# Altium schematic sheet PWR_M_V1.SchDoc
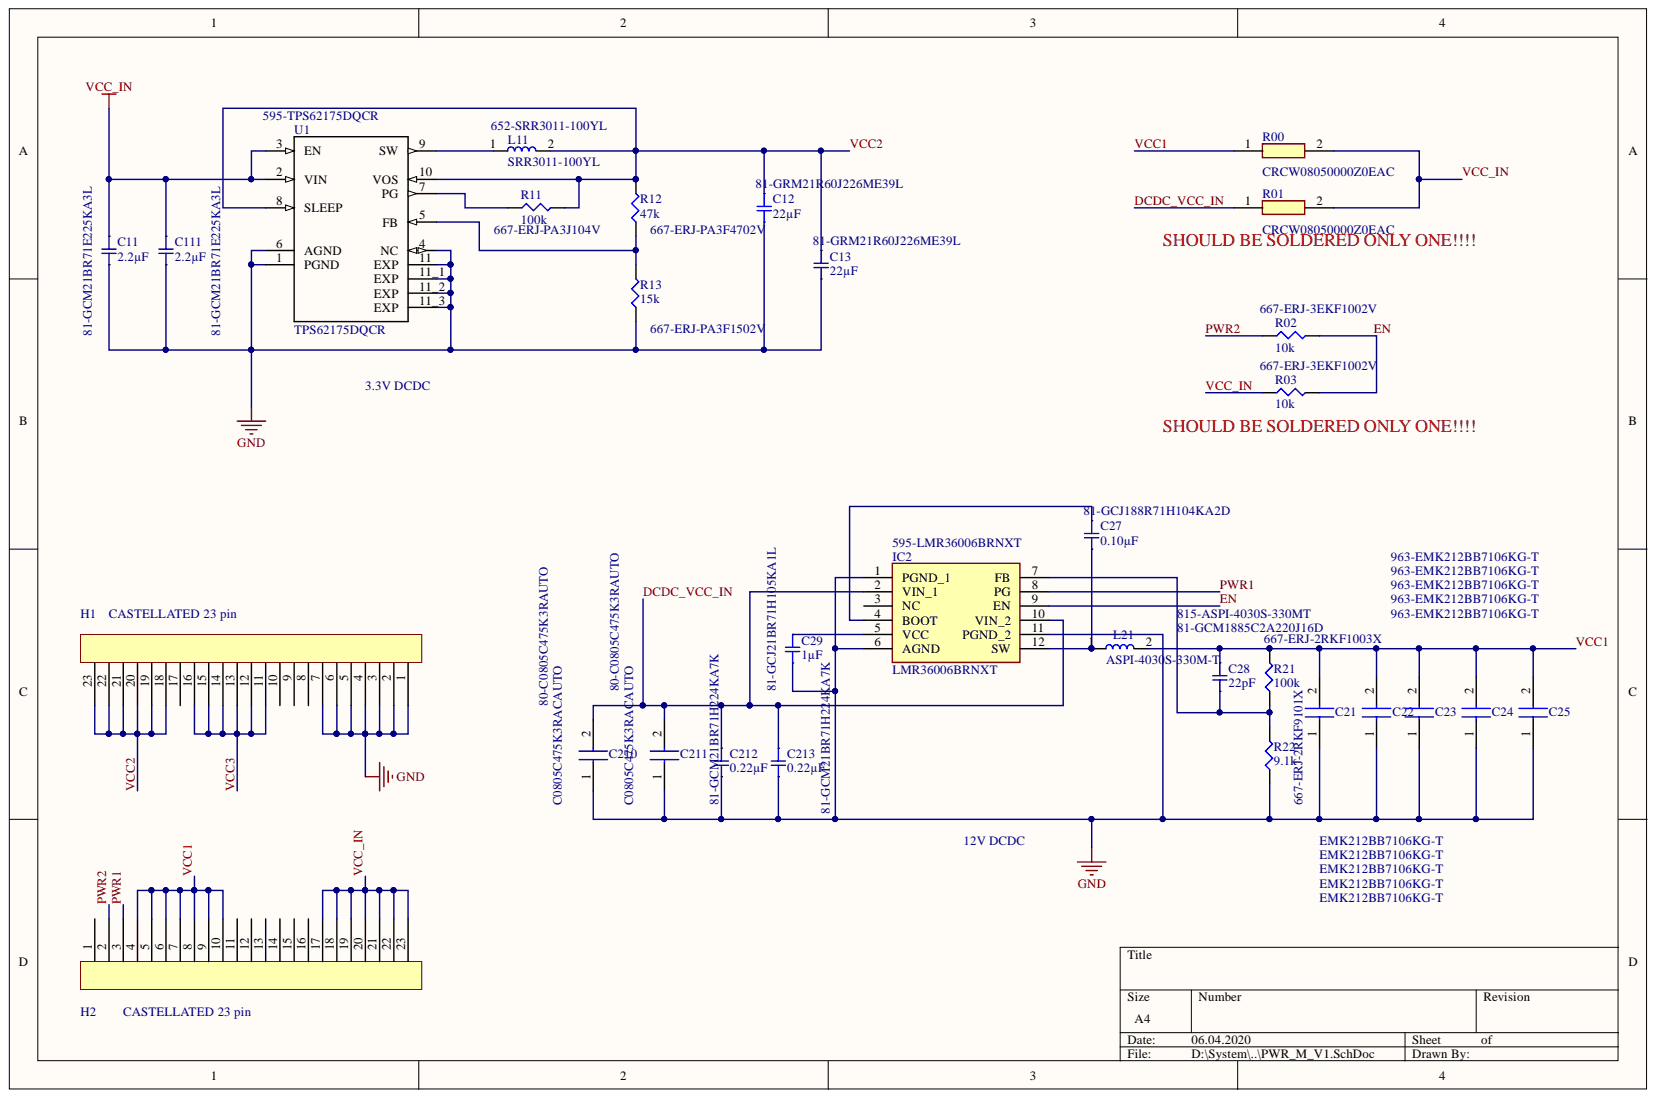
[diagram p0: PWR_M_V1.SchDoc]

## Parts
C11  2.2µF  Supplier Part Number 1: 81-GCM21BR71E225KA3L  [SMD-0805C]
C12  22µF  Supplier Part Number 1: 81-GRM21R60J226ME39L  [SMD-0805C]
C13  22µF  Supplier Part Number 1: 81-GRM21R60J226ME39L  [SMD-0805C]
C21  EMK212BB7106KG-T  [CAPC2012X135N]
C22  EMK212BB7106KG-T  [CAPC2012X135N]
C23  EMK212BB7106KG-T  [CAPC2012X135N]
C24  EMK212BB7106KG-T  [CAPC2012X135N]
C25  EMK212BB7106KG-T  [CAPC2012X135N]
C27  0.10µF  Supplier Part Number 1: 81-GCJ188R71H104KA2D  [SMD-0603C]
C28  22pF  [SMD-0603C]
C29  1µF  Supplier Part Number 1: 81-GCJ21BR71H105KA1L  [SMD-0805C]
C111  2.2µF  [SMD-0805C]
C210  C0805C475K3RACAUTO  [CAPC2012X125N]
C211  C0805C475K3RACAUTO  [CAPC2012X125N]
C212  0.22µF  Supplier Part Number 1: 81-GCM21BR71H224KA7K  [SMD-0805C]
C213  0.22µF  [SMD-0805C]
H1  CASTELLATED 23 pin  [CASTELLATED 23 pin]
H2  CASTELLATED 23 pin  [CASTELLATED 23 pin]
IC2  LMR36006BRNXT  Mouser2 Part Number: 595-LMR36006BRNXT  [LMR36015FSCQRNXTQ1]
L11  SRR3011-100YL  Supplier Part Number 1: 652-SRR3011-100YL  [SRR3011-100YL]
L21  ASPI-4030S-330M-T  [INDPM4040X300N]
R00  CRCW08050000Z0EAC  [RESC2012X60N]
R01  CRCW08050000Z0EAC  [RESC2012X60N]
R02  10k  Supplier Part Number 1: 667-ERJ-3EKF1002V  [SMD-0603-RES]
R03  10k  Supplier Part Number 1: 667-ERJ-3EKF1002V  [SMD-0603-RES]
R11  100k  Supplier Part Number 1: 667-ERJ-PA3J104V  [SMD-0603-RES]
R12  47k  [SMD-0603-RES]
R13  15k  Supplier Part Number 1: 667-ERJ-PA3F1502V  [SMD-0603-RES]
R21  100k  Supplier Part Number 1: 667-ERJ-2RKF1003X  [SMD-0402-RES]
R22  9.1k  Supplier Part Number 1: 667-ERJ-2RKF9101X  [SMD-0402-RES]
U1  TPS62175DQCR  Supplier Part Number 1: 595-TPS62175DQCR  [*SON50P300X200X80-11N240X84_TPS62175DQCR(Primary)]

## Nets
GND: C11.2 C12.2 C13.2 C21.1 C22.1 C23.1 C24.1 C25.1 C29.2 C111.2 C210.1 C211.1 C212.2 C213.2 H1.1 H1.2 H1.3 H1.4 H1.5 H1.6 H1.7 IC2.1(PGND_1) IC2.6(AGND) IC2.11(PGND_2) R13.1 R22.1 U1.1(PGND) U1.4(NC) U1.6(AGND) U1.11(EXP) U1.11_1(EXP) U1.11_2(EXP) U1.11_3(EXP)
DCDC_VCC_IN: C210.2 C211.2 C212.1 C213.1 IC2.2(VIN_1) IC2.10(VIN_2) R01.1
EN: IC2.9(EN) R02.2 R03.2
PWR1: H2.3 IC2.8(PG)
PWR2: H2.2 R02.1
VCC1: C21.2 C22.2 C23.2 C24.2 C25.2 C28.1 H2.4 H2.5 H2.6 H2.7 H2.8 H2.9 H2.10 L21.2 R00.1 R21.2
VCC2: C12.1 C13.1 H1.18 H1.19 H1.20 H1.21 H1.22 H1.23 L11.2 R11.2 R12.2 U1.8(SLEEP) U1.10(VOS)
VCC3: H1.11 H1.12 H1.13 H1.14 H1.15 H1.16
VCC_IN: C11.1 C111.1 H2.17 H2.18 H2.19 H2.20 H2.21 H2.22 H2.23 R00.2 R01.2 R03.1 U1.2(VIN) U1.3(EN)
NetC27_1: C27.1 IC2.4(BOOT)
NetC27_2: C27.2 IC2.12(SW) L21.1
NetC28_2: C28.2 IC2.7(FB) R21.1 R22.2
NetC29_1: C29.1 IC2.5(VCC)
NetL11_1: L11.1 U1.9(SW)
NetR11_1: R11.1 U1.7(PG)
NetR12_1: R12.1 R13.2 U1.5(FB)

Not connected: H1 8 9 10 17; H2 1 11 12 13 14 15 16; IC2 3(NC)

## Library data of the parts
C11 C111 = GCM21BR71E225KA73L: CAP CER 2.2UF 25V X7R 0805; Manufacturer: Murata Electronics North America; @ qty: 0; Minimum Quantity: 1; Tolerance: ±10%; Unit Price (USD): 0.35; Applications: Automotive; Component Kind: Standard; Datasheets: http://www.murata.com/~/media/webrenewal/support/library/catalog/products/capacitor/mlcc/c03e.ashx; Digi-Key Part Number: 490-4787-1-ND; Factory Stock: 0; Features: -; Height - Seated (Max): -; Image: //media.digikey.com/photos/Murata%20Photos/NCP,GCM%20SERIES%200805.jpg; Lead Spacing: -; Lead Style: -; Library Name: capacitor_murata_0805.IntLib; Mounting Type: Surface Mount, MLCC; Operating Temperature: -55°C ~ 125°C; Package / Case: 0805 (2012 Metric); Packaging: Cut Tape (CT); Part Number: GCM21BR71E225KA73L; Part Status: Active; Quantity Available: 18461; Ratings: AEC-Q200; Series: GCM; Size / Dimension: 0.079" L x 0.049" W (2.00mm x 1.25mm); Supplier 1: Mouser; Temperature Coefficient: X7R; Thickness (Max): 0.055" (1.40mm); Value: 2.2µF; Voltage: 25V
  C111: Supplier Part Number 1: 81-GCM21BR71E225KA3L
C12 C13 = GRM21BR60J226ME39L: CAP CER 22UF 6.3V X5R 0805; Manufacturer: Murata Electronics North America; @ qty: 0; Database Table Name: capacitor_murata_0805$; Minimum Quantity: 1; Tolerance: ±20%; Unit Price (USD): 0,24; Applications: General Purpose; Component Kind: Standard; Datasheets: http://www.murata.com/~/media/webrenewal/support/library/catalog/products/capacitor/mlcc/c02e.pdf; Digi-Key Part Number: 490-1719-1-ND; Factory Stock: 0; Features: -; Height - Seated (Max): -; Image: //media.digikey.com/Renders/Murata%20Renders/0805%20(2012%20Metric)%20.85mm.jpg; Lead Spacing: -; Lead Style: -; Library Name: cap_murata.DbLib; Mounting Type: Surface Mount, MLCC; Operating Temperature: -55°C ~ 85°C; Package / Case: 0805 (2012 Metric); Packaging: Cut Tape (CT); Part Number: GRM21BR60J226ME39L; Part Status: Active; Quantity Available: 983766; Ratings: -; Series: GRM; Size / Dimension: 0.079" L x 0.049" W (2.00mm x 1.25mm); Supplier 1: Mouser; Temperature Coefficient: X5R; Thickness (Max): 0.053" (1.35mm); Value: 22µF; Voltage: 6.3V
C21-C25 = EMK212BB7106KG-T: Capacitor; Manufacturer_Name: TAIYO YUDEN; Component Kind: Standard; Height: 1.35mm; Manufacturer_Part_Number: EMK212BB7106KG-T; Supplier 1: Mouser; Supplier Part Number 1: 963-EMK212BB7106KG-T
C27 = GCJ188R71H104KA12D: CAP CER 0.1UF 50V X7R 0603; Manufacturer: Murata Electronics North America; @ qty: 0; Minimum Quantity: 1; Tolerance: ±10%; Unit Price (USD): 0.12; Applications: Automotive, Boardflex Sensitive; Component Kind: Standard; Datasheets: http://www.murata.com/~/media/webrenewal/support/library/catalog/products/capacitor/mlcc/c03e.ashx; Digi-Key Part Number: 490-5798-1-ND; Factory Stock: 0; Features: Soft Termination; Height - Seated (Max): -; Image: //media.digikey.com/Renders/Murata%20Renders/0603%28LQG18%29.jpg; Lead Spacing: -; Lead Style: -; Library Name: capacitor_murata_0603.IntLib; Mounting Type: Surface Mount, MLCC; Operating Temperature: -55°C ~ 125°C; Package / Case: 0603 (1608 Metric); Packaging: Cut Tape (CT); Part Number: GCJ188R71H104KA12D; Part Status: Active; Quantity Available: 163695; Ratings: AEC-Q200; Series: GCJ; Size / Dimension: 0.063" L x 0.031" W (1.60mm x 0.80mm); Supplier 1: Mouser; Temperature Coefficient: X7R; Thickness (Max): 0.035" (0.90mm); Value: 0.10µF; Voltage: 50V
C28 = GCM1885C2A220JA16D: CAP CER 22PF 100V NP0 0603; Manufacturer: Murata Electronics North America; @ qty: 0; Minimum Quantity: 1; Temperature Coefficient: C0G, NP0; Tolerance: ±5%; Unit Price (USD): 0.11; Applications: Automotive; Component Kind: Standard; Datasheets: http://www.murata.com/~/media/webrenewal/support/library/catalog/products/capacitor/mlcc/c03e.ashx; Digi-Key Part Number: 490-4942-1-ND; Factory Stock: 0; Features: -; Height - Seated (Max): -; Image: //media.digikey.com/Renders/Murata%20Renders/0603%28GCM188%29.jpg; Lead Spacing: -; Lead Style: -; Library Name: capacitor_murata_0603.IntLib; Mounting Type: Surface Mount, MLCC; Operating Temperature: -55°C ~ 125°C; Package / Case: 0603 (1608 Metric); Packaging: Cut Tape (CT); Part Number: GCM1885C2A220JA16D; Part Status: Active; Quantity Available: 39916; Ratings: AEC-Q200; Series: GCM; Size / Dimension: 0.063" L x 0.031" W (1.60mm x 0.80mm); Supplier 1: Mouser; Supplier Part Number 1: 81-GCM1885C2A220J16D; Thickness (Max): 0.035" (0.90mm); Value: 22pF; Voltage: 100V
C29 = GCJ21BR71H105KA01L: CAP CER 1UF 50V X7R 0805; Manufacturer: Murata Electronics North America; @ qty: 0; Minimum Quantity: 1; Tolerance: ±10%; Unit Price (USD): 0.33; Applications: Automotive, Boardflex Sensitive; Component Kind: Standard; Datasheets: http://www.murata.com/~/media/webrenewal/support/library/catalog/products/capacitor/mlcc/c03e.ashx; Digi-Key Part Number: 490-10667-1-ND; Factory Stock: 0; Features: Soft Termination; Height - Seated (Max): -; Image: //media.digikey.com/Renders/Murata%20Renders/0805%20(2012%20Metric)%20.85mm.jpg; Lead Spacing: -; Lead Style: -; Library Name: capacitor_murata_0805.IntLib; Mounting Type: Surface Mount, MLCC; Operating Temperature: -55°C ~ 125°C; Package / Case: 0805 (2012 Metric); Packaging: Cut Tape (CT); Part Number: GCJ21BR71H105KA01L; Part Status: Active; Quantity Available: 55137; Ratings: AEC-Q200; Series: GCJ; Size / Dimension: 0.079" L x 0.049" W (2.00mm x 1.25mm); Supplier 1: Mouser; Temperature Coefficient: X7R; Thickness (Max): 0.057" (1.45mm); Value: 1µF; Voltage: 50V
C210 C211 = C0805C475K3RACAUTO: Capacitor; Manufacturer_Name: Kemet; Component Kind: Standard; Datasheet Link: https://componentsearchengine.com/Datasheets/1/C0805C475K3RACAUTO.pdf; Height: 1.25mm; Manufacturer_Part_Number: C0805C475K3RACAUTO; Mouser2 Part Number: 80-C0805C475K3RAUTO; Mouser2 Price/Stock: https://www.mouser.com/Search/Refine.aspx?Keyword=80-C0805C475K3RAUTO; Supplier 1: Mouser; Supplier Part Number 1: 80-C0805C475K3RAUTO
C212 C213 = GCM21BR71H224KA37K: CAP CER 0.22UF 50V X7R 0805; Manufacturer: Murata Electronics North America; @ qty: 0; Minimum Quantity: 1; Tolerance: ±10%; Unit Price (USD): 0.24; Applications: Automotive; Component Kind: Standard; Datasheets: http://www.murata.com/~/media/webrenewal/support/library/catalog/products/capacitor/mlcc/c03e.ashx; Digi-Key Part Number: 490-13311-1-ND; Factory Stock: 0; Features: -; Height - Seated (Max): -; Image: //media.digikey.com/photos/Murata%20Photos/NCP,GCM%20SERIES%200805.jpg; Lead Spacing: -; Lead Style: -; Library Name: capacitor_murata_0805.IntLib; Mounting Type: Surface Mount, MLCC; Operating Temperature: -55°C ~ 125°C; Package / Case: 0805 (2012 Metric); Packaging: Cut Tape (CT); Part Number: GCM21BR71H224KA37K; Part Status: Active; Quantity Available: 9007; Ratings: AEC-Q200; Series: GCM; Size / Dimension: 0.079" L x 0.049" W (2.00mm x 1.25mm); Supplier 1: Mouser; Temperature Coefficient: X7R; Thickness (Max): 0.055" (1.40mm); Value: 0.22µF; Voltage: 50V
  C213: Supplier Part Number 1: 81-GCM21BR71H224KA7K
IC2 = LMR36006BRNXT: Integrated Circuit; Manufacturer_Name: Texas Instruments; Component Kind: Standard; Datasheet Link: http://www.ti.com/lit/gpn/LMR36006; Height: 0.9mm; Manufacturer_Part_Number: LMR36006BRNXT; Mouser2 Price/Stock: https://www.mouser.com/Search/Refine.aspx?Keyword=595-LMR36006BRNXT; Supplier 1: Mouser; Supplier Part Number 1: 595-LMR36006BRNXT
R00 R01 = CRCW08050000Z0EAC: Resistor; Manufacturer_Name: Vishay; Component Kind: Standard; Datasheet Link: https://componentsearchengine.com/Datasheets/1/CRCW08050000Z0EAC.pdf; Height: 0.6mm; Manufacturer_Part_Number: CRCW08050000Z0EAC; Mouser2 Part Number: 71-CRCW08050000Z0EAC; Mouser2 Price/Stock: https://www.mouser.com/Search/Refine.aspx?Keyword=71-CRCW08050000Z0EAC
R02 R03 = ERJ-3EKF1002V: RES SMD 10K OHM 1% 1/10W 0603; Manufacturer: Panasonic Electronic Components; @ qty: 1500; Factory Stock: 0; Minimum Quantity: 1; Power (Watts): 0.1W, 1/10W; Temperature Coefficient: ±100ppm/°C; Unit Price (USD): 0.00379; Component Kind: Standard; Composition: Thick Film; Datasheets: http://industrial.panasonic.com/www-cgi/jvcr13pz.cgi?E+PZ+3+AOA0002+ERJ3EKF1002V+7+WW; Digi-Key Part Number: P10.0KHCT-ND; Failure Rate: -; Features: Automotive AEC-Q200; Height - Seated (Max): 0.022" (0.55mm); Image: //media.digikey.com/Photos/Panasonic%20Photos/MFG_ERJ-P6W%20Series.jpg; Library Name: Panasonic_ERJ_0603.IntLib; Number of Terminations: 2; Operating Temperature: -55°C ~ 155°C; Package / Case: 0603 (1608 Metric); Packaging: Cut Tape (CT); Part Number: ERJ-3EKF1002V; Part Status: Active; Quantity Available: 6703141; Series: ERJ; Size / Dimension: 0.063" L x 0.031" W (1.60mm x 0.80mm); Supplier 1: Mouser; Supplier Device Package: 0603; Tolerance: ±1%; Value: 10k
R11 = ERJ-PA3J104V: RES SMD 100K OHM 5% 1/4W 0603; Manufacturer: Panasonic Electronic Components; @ qty: 1500; Factory Stock: 0; Minimum Quantity: 1; Power (Watts): 0.25W, 1/4W; Temperature Coefficient: ±200ppm/°C; Unit Price (USD): 0.01646; Component Kind: Standard; Composition: Thick Film; Datasheets: https://industrial.panasonic.com/cdbs/www-data/pdf/RDA0000/AOA0000C243.pdf; Digi-Key Part Number: P100KBZCT-ND; Failure Rate: -; Features: Automotive AEC-Q200, Pulse Withstanding; Height - Seated (Max): 0.022" (0.55mm); Image: //media.digikey.com/Photos/Panasonic%20Photos/MFG_ERJ-P6W%20Series.jpg; Library Name: Panasonic_ERJ_0603.IntLib; Number of Terminations: 2; Operating Temperature: -55°C ~ 155°C; Package / Case: 0603 (1608 Metric); Packaging: Cut Tape (CT); Part Number: ERJ-PA3J104V; Part Status: Active; Quantity Available: 4793; Series: ERJ; Size / Dimension: 0.063" L x 0.031" W (1.60mm x 0.80mm); Supplier 1: Mouser; Supplier Device Package: 0603; Tolerance: ±5%; Value: 100k
R12 = ERJ-PA3F4702V: RES SMD 47K OHM 1% 1/4W 0603; Manufacturer: Panasonic Electronic Components; @ qty: 1500; Factory Stock: 0; Minimum Quantity: 1; Power (Watts): 0.25W, 1/4W; Temperature Coefficient: ±100ppm/°C; Unit Price (USD): 0.02372; Component Kind: Standard; Composition: Thick Film; Datasheets: https://industrial.panasonic.com/cdbs/www-data/pdf/RDA0000/AOA0000C243.pdf; Digi-Key Part Number: P47KBYCT-ND; Failure Rate: -; Features: Automotive AEC-Q200, Pulse Withstanding; Height - Seated (Max): 0.022" (0.55mm); Image: //media.digikey.com/Photos/Panasonic%20Photos/MFG_ERJ-P6W%20Series.jpg; Library Name: Panasonic_ERJ_0603.IntLib; Number of Terminations: 2; Operating Temperature: -55°C ~ 155°C; Package / Case: 0603 (1608 Metric); Packaging: Cut Tape (CT); Part Number: ERJ-PA3F4702V; Part Status: Active; Quantity Available: 7124; Series: ERJ; Size / Dimension: 0.063" L x 0.031" W (1.60mm x 0.80mm); Supplier 1: Mouser; Supplier Device Package: 0603; Supplier Part Number 1: 667-ERJ-PA3F4702V; Tolerance: ±1%; Value: 47k
R13 = ERJ-PA3F1502V: RES SMD 15K OHM 1% 1/4W 0603; Manufacturer: Panasonic Electronic Components; @ qty: 1500; Factory Stock: 0; Minimum Quantity: 1; Power (Watts): 0.25W, 1/4W; Temperature Coefficient: ±100ppm/°C; Unit Price (USD): 0.02372; Component Kind: Standard; Composition: Thick Film; Datasheets: https://industrial.panasonic.com/cdbs/www-data/pdf/RDA0000/AOA0000C243.pdf; Digi-Key Part Number: P15KBYCT-ND; Failure Rate: -; Features: Automotive AEC-Q200, Pulse Withstanding; Height - Seated (Max): 0.022" (0.55mm); Image: //media.digikey.com/Photos/Panasonic%20Photos/MFG_ERJ-P6W%20Series.jpg; Library Name: Panasonic_ERJ_0603.IntLib; Number of Terminations: 2; Operating Temperature: -55°C ~ 155°C; Package / Case: 0603 (1608 Metric); Packaging: Cut Tape (CT); Part Number: ERJ-PA3F1502V; Part Status: Active; Quantity Available: 8940; Series: ERJ; Size / Dimension: 0.063" L x 0.031" W (1.60mm x 0.80mm); Supplier 1: Mouser; Supplier Device Package: 0603; Tolerance: ±1%; Value: 15k
R21 = ERJ-2RKF1003X: RES SMD 100K OHM 1% 1/10W 0402; Manufacturer: Panasonic Electronic Components; @ qty: 1500; Factory Stock: 0; Minimum Quantity: 1; Power (Watts): 0.1W, 1/10W; Temperature Coefficient: ±100ppm/°C; Unit Price (USD): 0.00496; Component Kind: Standard; Composition: Thick Film; Datasheets: http://industrial.panasonic.com/www-cgi/jvcr13pz.cgi?E+PZ+3+AOA0002+ERJ2RKF1003X+7+WW; Digi-Key Part Number: P100KLCT-ND; Failure Rate: -; Features: Automotive AEC-Q200; Height - Seated (Max): 0.016" (0.40mm); Image: //media.digikey.com/Photos/Panasonic%20Photos/ERJ-PA2-Series.jpg; Library Name: Panasonic_ERJ_0402.IntLib; Number of Terminations: 2; Operating Temperature: -55°C ~ 155°C; Package / Case: 0402 (1005 Metric); Packaging: Cut Tape (CT); Part Number: ERJ-2RKF1003X; Part Status: Active; Quantity Available: 2645965; Series: ERJ; Size / Dimension: 0.039" L x 0.020" W (1.00mm x 0.50mm); Supplier 1: Mouser; Supplier Device Package: 0402; Tolerance: ±1%; Value: 100k
R22 = ERJ-2RKF9101X: RES SMD 9.1K OHM 1% 1/10W 0402; Manufacturer: Panasonic Electronic Components; @ qty: 1500; Factory Stock: 0; Minimum Quantity: 1; Power (Watts): 0.1W, 1/10W; Temperature Coefficient: ±100ppm/°C; Unit Price (USD): 0.00496; Component Kind: Standard; Composition: Thick Film; Datasheets: http://industrial.panasonic.com/www-cgi/jvcr13pz.cgi?E+PZ+3+AOA0002+ERJ2RKF9101X+7+WW; Digi-Key Part Number: P9.10KLCT-ND; Failure Rate: -; Features: Automotive AEC-Q200; Height - Seated (Max): 0.016" (0.40mm); Image: //media.digikey.com/Photos/Panasonic%20Photos/ERJ-PA2-Series.jpg; Library Name: Panasonic_ERJ_0402.IntLib; Number of Terminations: 2; Operating Temperature: -55°C ~ 155°C; Package / Case: 0402 (1005 Metric); Packaging: Cut Tape (CT); Part Number: ERJ-2RKF9101X; Part Status: Active; Quantity Available: 92711; Series: ERJ; Size / Dimension: 0.039" L x 0.020" W (1.00mm x 0.50mm); Supplier 1: Mouser; Supplier Device Package: 0402; Tolerance: ±1%; Value: 9.1k
U1 = TPS62175DQCR: 28V, 0.5A Step-Down Converter with SNOOZE Mode 10-WSON -40 to 85; MF: Texas Instruments; Availability: Unavailable; Component Kind: Standard; Library Name: TPS62175DQCR.IntLib; MP: TPS62175DQCR; Package: WSON-10 Texas Instruments; Price: None; SnapEDA_Link: https://www.snapeda.com/parts/TPS62175DQCR/Texas%20Instruments/view-part/?ref=snap; Supplier 1: Mouser
H1 H2 = CASTELLATED 23 pin: Component Kind: Standard; Library Name: USIB.SchLib
L11 = SRR3011-100YL: Component Kind: Standard; Library Name: SRR3011-100YL.SchLib; Supplier 1: Mouser
L21 = ASPI-4030S-330M-T: Component Kind: Standard; Library Name: SamacSys.SchLib; Supplier 1: Mouser; Supplier Part Number 1: 815-ASPI-4030S-330MT
